# Altium schematic sheet WiFi.SchDoc
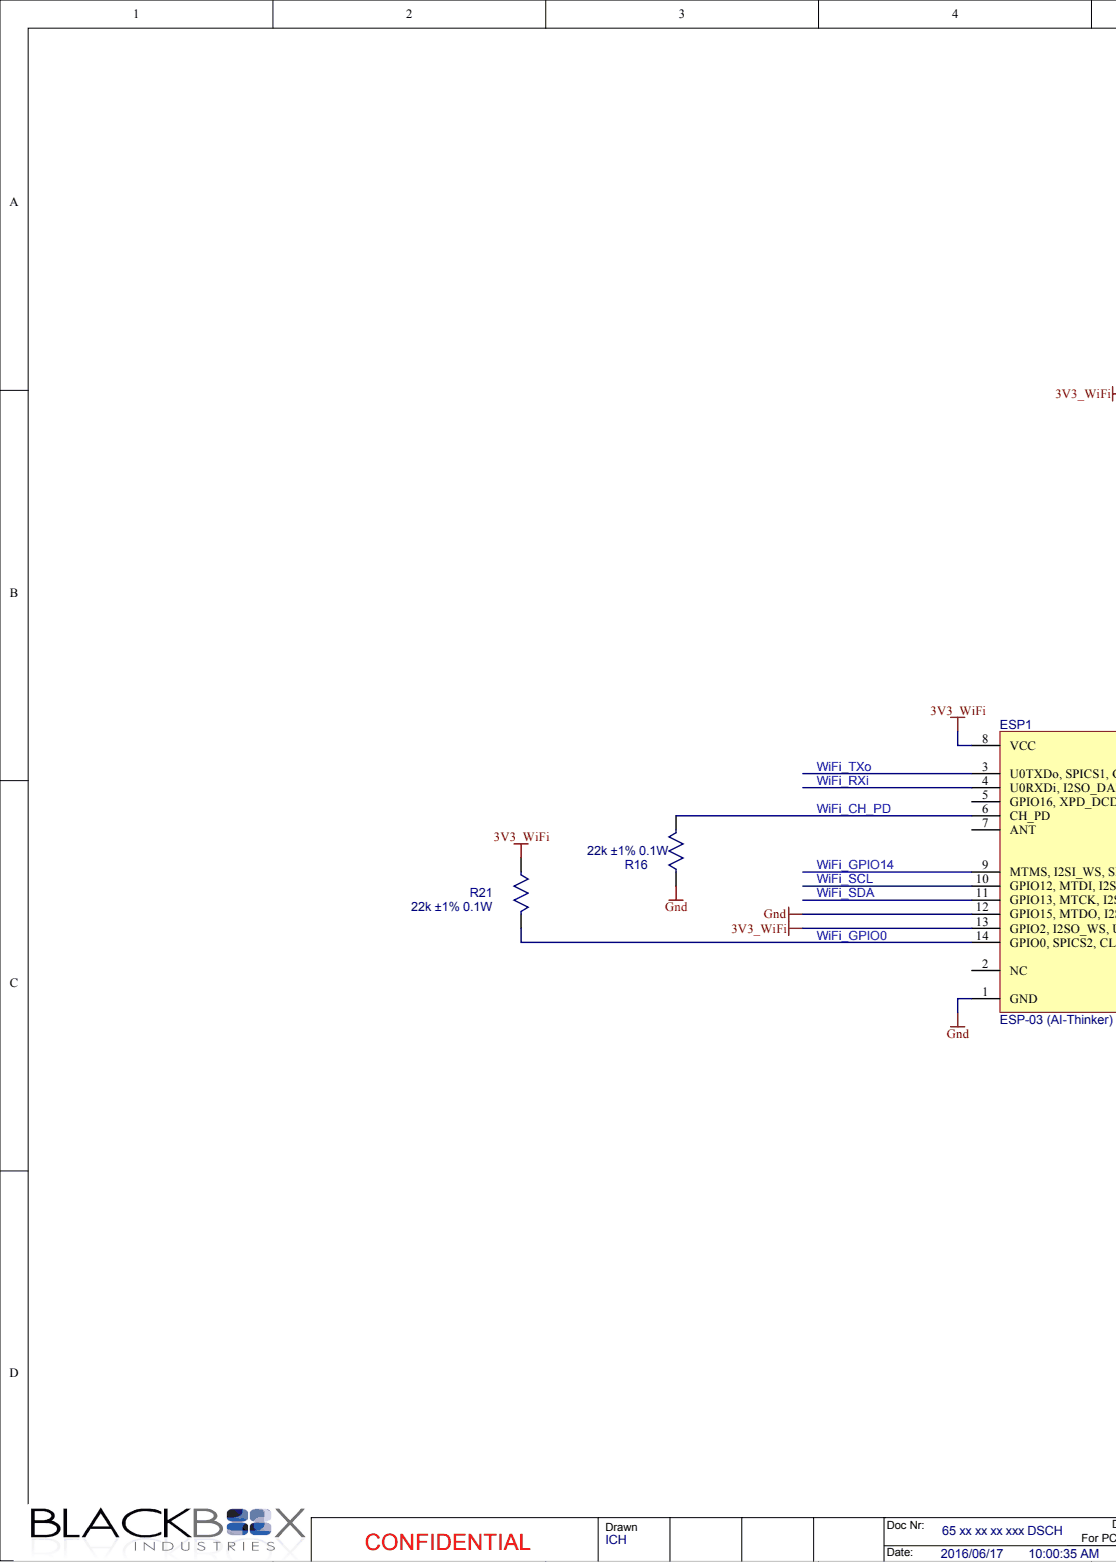
[diagram p0: WiFi.SchDoc, left]
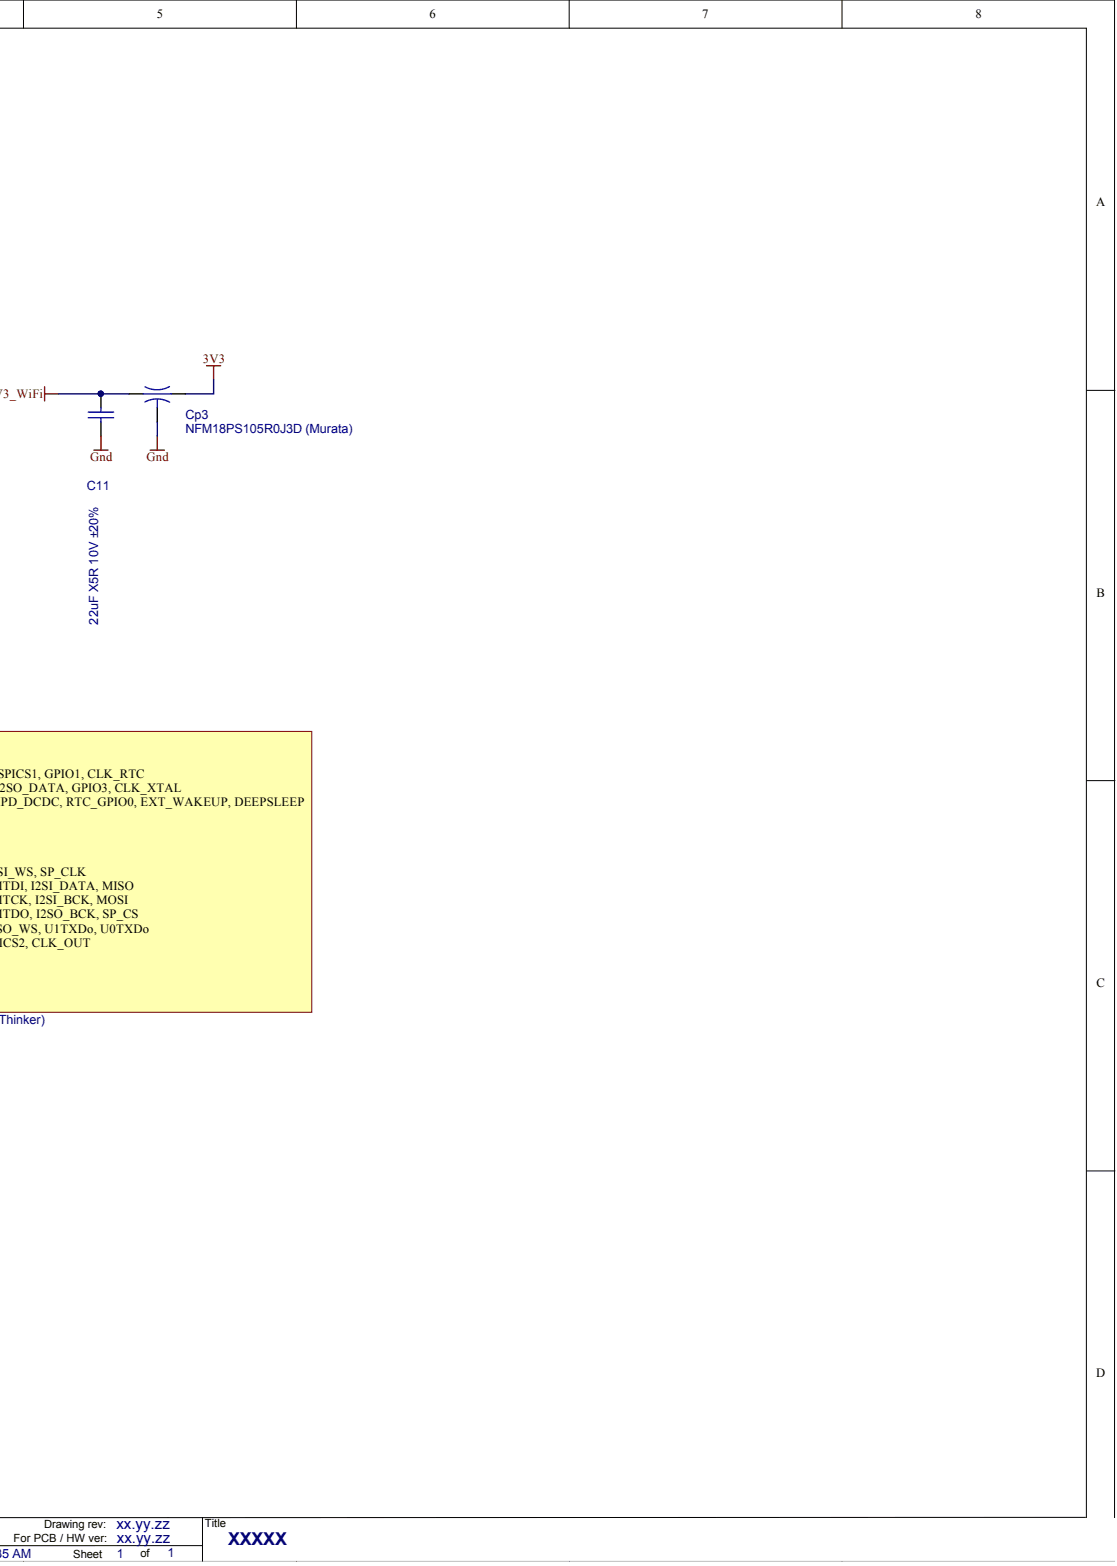
[diagram p1: WiFi.SchDoc, right]

## Parts
C11  22uF X5R 10V ±20%  [0603_CAP]
Cp3  NFM18PS105R0J3D (Murata)  [NFM18PS (Murata)]
ESP1  ESP-03 (AI-Thinker)  [ESP-03]
R16  22k ±1% 0.1W  [0603_RES]
R21  22k ±1% 0.1W  [0603_RES]

## Nets
3V3: Cp3.2
3V3_WIFI: C11.1 Cp3.1 ESP1.8(VCC) ESP1.13(GPIO2,_I2SO_WS,_U1TXDo,_U0TXDo) R21.1
GND: C11.2 Cp3.3 ESP1.1(GND) ESP1.12(GPIO15,_MTDO,_I2SO_BCK,_SP_CS) R16.1
WIFI_CH_PD: ESP1.6(CH_PD) R16.2
WIFI_GPIO0: ESP1.14(GPIO0,_SPICS2,_CLK_OUT) R21.2
WIFI_GPIO14: ESP1.9(MTMS,_I2SI_WS,_SP_CLK)
WIFI_RXI: ESP1.4(U0RXDi,_I2SO_DATA,_GPIO3,_CLK_XTAL)
WIFI_SCL: ESP1.10(GPIO12,_MTDI,_I2SI_DATA,_MISO)
WIFI_SDA: ESP1.11(GPIO13,_MTCK,_I2SI_BCK,_MOSI)
WIFI_TXO: ESP1.3(U0TXDo,_SPICS1,_GPIO1,_CLK_RTC)

Not connected: ESP1 2(NC) 5(GPIO16,_XPD_DCDC,_RTC_GPIO0,_EXT_WAKEUP,_DEEPSLEEP) 7(ANT)

## Library data of the parts
C11 = 22uF X5R 10V ±20%: Capacitor, ceramic 0603; Component Kind: Standard; descr: Capacitor, ceramic 0603; Supplier 1: Digi-Key; Supplier Part Number 1: 445-9077-1-ND; Library Name: Onecell Ig.IntLib
Cp3 = NFM18PS105R0J3D (Murata): Passthrough cap 1uF 2A 6.3V; ClassName: PassThroughCaps; Component Kind: Standard; descr: Passthrough cap 1uF 2A 6.3V; Supplier 1: Digi-Key; Supplier Part Number 1: 490-6974-1-ND; Library Name: Onecell Ig.IntLib
ESP1 = ESP-03 (AI-Thinker): WiFi ESP8266; Component Kind: Standard; descr: WiFi ESP8266; Library Name: Onecell Ig.IntLib
R16 R21 = 22k ±1% 0.1W: resistor, thickfilm; Component Kind: Standard; descr: resistor, thickfilm; Library Name: OneCell passives.IntLib; Supplier 1: Digi-Key; Supplier Part Number 1: 311-22.0KHRTR-ND
